# Altium schematic sheet USBINFRTransiever_IRTX.SchDoc
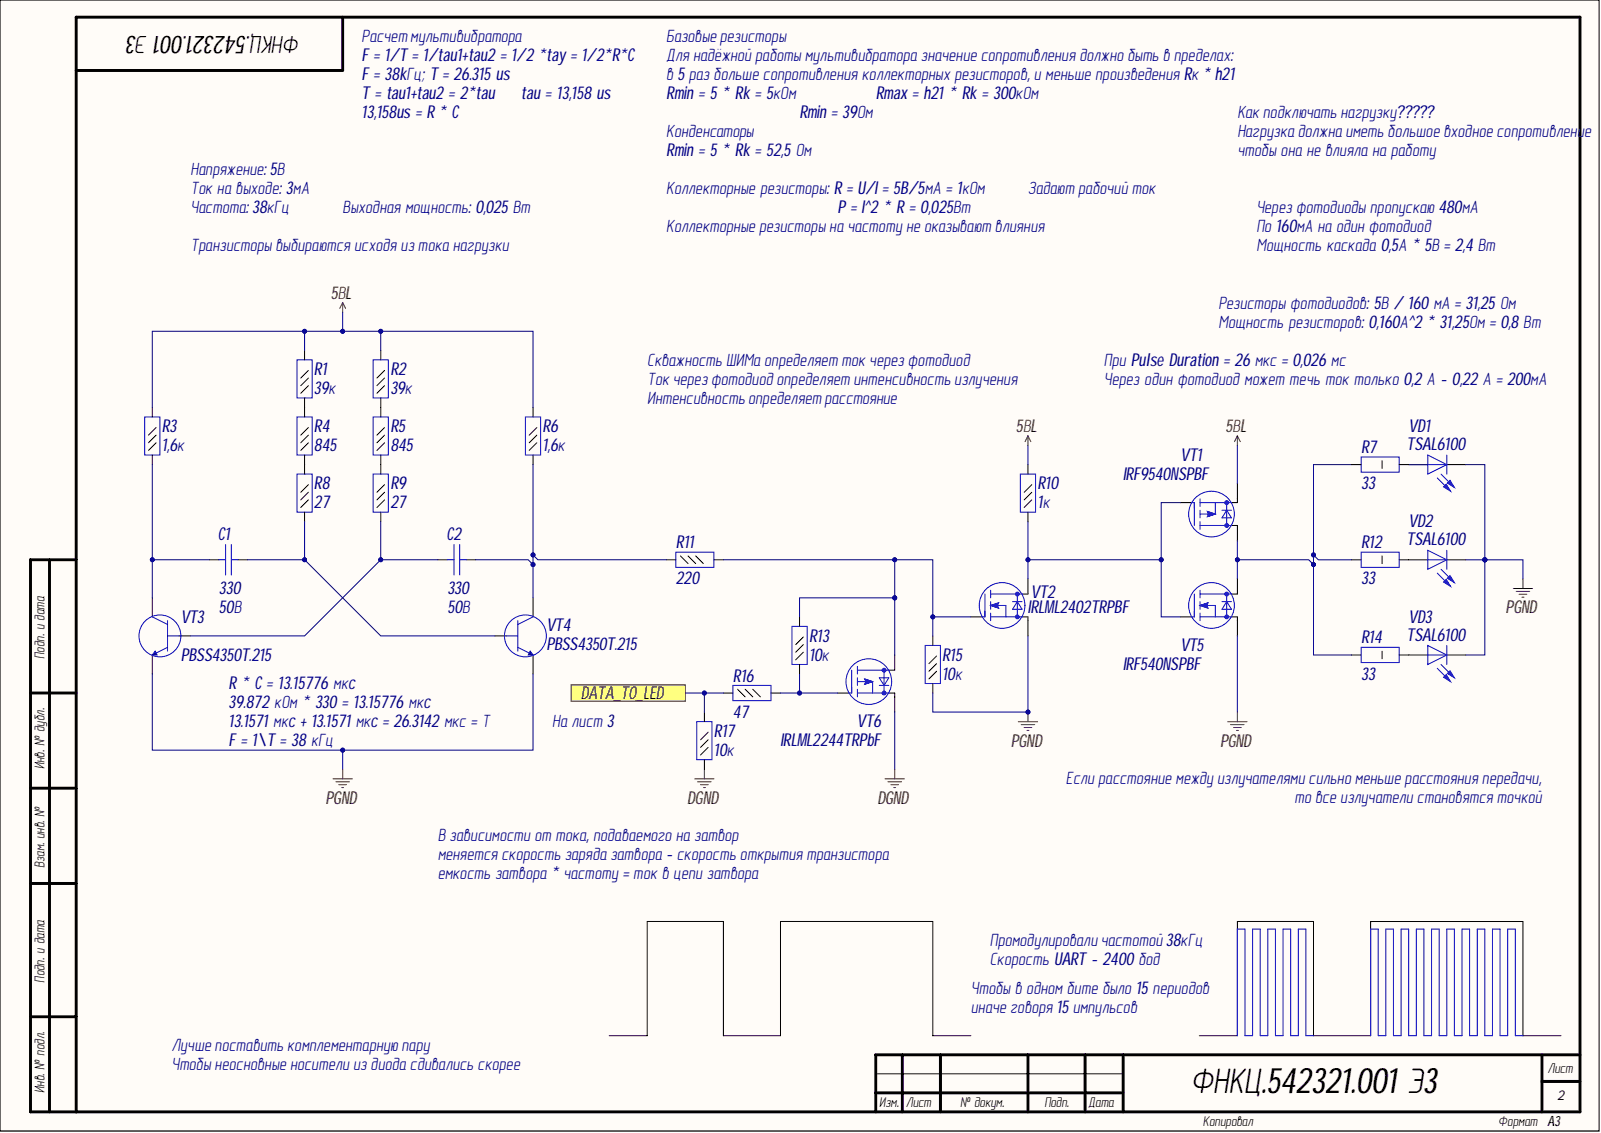
[diagram p0: USBINFRTransiever_IRTX.SchDoc]

## Parts
C1  value 330  [C0603]  (hidden comment: Capacitor)
C2  value 330  [C0603]  (hidden comment: Capacitor)
R1  value 39к  [R0603]  (hidden comment: Resistor_0,062Вт)
R2  value 39к  [R0603]  (hidden comment: Resistor_0,062Вт)
R3  value 1,6к  [R0603]  (hidden comment: Resistor_0,062Вт)
R4  value 845  [R0603]  (hidden comment: Resistor_0,062Вт)
R5  value 845  [R0603]  (hidden comment: Resistor_0,062Вт)
R6  value 1,6к  [R0603]  (hidden comment: Resistor_0,062Вт)
R7  value 33  [R2512]  (hidden comment: Resistor_1Вт)
R8  value 27  [R0603]  (hidden comment: Resistor_0,062Вт)
R9  value 27  [R0603]  (hidden comment: Resistor_0,062Вт)
R10  value 1к  [R0603]  (hidden comment: Resistor_0,062Вт)
R11  value 220  [R0603]  (hidden comment: Resistor_0,062Вт)
R12  value 33  [R2512]  (hidden comment: Resistor_1Вт)
R13  value 10к  [R0603]  (hidden comment: Resistor_0,062Вт)
R14  value 33  [R2512]  (hidden comment: Resistor_1Вт)
R15  value 10к  [R0603]  (hidden comment: Resistor_0,062Вт)
R16  value 47  [R0603]  (hidden comment: Resistor_0,062Вт)
R17  value 10к  [R0603]  (hidden comment: Resistor_0,062Вт)
VD1  Part Number: TSAL6100  [RADIAL_5MM]  (hidden comment: LED)
VD2  Part Number: TSAL6100  [RADIAL_5MM]  (hidden comment: LED)
VD3  Part Number: TSAL6100  [RADIAL_5MM]  (hidden comment: LED)
VT1  Part Number: IRF9540NSPBF  [D2PAK]  (hidden comment: P-MOSFET)
VT2  Part Number: IRLML2402TRPBF  [SOT23-3]  (hidden comment: N-MOSFET)
VT3  Part Number: PBSS4350T.215  [SOT23-3]  (hidden comment: BJT NPN)
VT4  Part Number: PBSS4350T.215  Vceo max: 50В  [SOT23-3]  (hidden comment: BJT NPN)
VT5  Part Number: IRF540NSPBF  [D2PAK]  (hidden comment: N-MOSFET)
VT6  Part Number: IRLML2244TRPbF  [SOT23-3]  (hidden comment: P-MOSFET)

## Ports
DATA_TO_LED

## Nets
5ВL: R1.2 R2.2 R3.2 R6.2 R10.2 VT1.2
DGND: R17.1 VT6.2
PGND: R15.1 VD1.1 VD2.1 VD3.1 VT2.2 VT3.2 VT4.2 VT5.2
DATA_TO_LED: R16.1 R17.2 ; port DATA_TO_LED
NetC1_1: C1.1 R3.1 VT3.3
NetC1_2: C1.2 R8.1 VT4.1
NetC2_1: C2.1 R9.1 VT3.1
NetC2_2: C2.2 R6.1 R11.1 VT4.3
NetR1_1: R1.1 R4.2
NetR2_1: R2.1 R5.2
NetR4_1: R4.1 R8.2
NetR5_1: R5.1 R9.2
NetR7_1: R7.1 R12.1 R14.1 VT1.3 VT5.3
NetR7_2: R7.2 VD1.2
NetR10_1: R10.1 VT1.1 VT2.3 VT5.1
NetR11_2: R11.2 R13.2 R15.2 VT2.1 VT6.3
NetR12_2: R12.2 VD2.2
NetR13_1: R13.1 R16.2 VT6.1
NetR14_2: R14.2 VD3.2

## Library data of the parts
C1 C2 = cap215: Конденсатор; Manufacture: Murata; Part Name: Ceramic; Part Number: GRM188R71H331KA01D; Database Table Name: Capacitors$; TKE: X7R; Voltage: 50В; Component Kind: Standard; id: cap215; Library Name: MOP44.DbLib
R1 R2 = res497: Резистор; Manufacture: Yageo; Part Number: RC0603FR-0739KL; Accuracy: 1%; Database Table Name: Resistor$; Component Kind: Standard; id: res497; Library Name: MOP44.DbLib; Part Name: Resistor; Power: 0,062Вт
R3 R6 = res464: Резистор; Manufacture: Yageo; Part Number: RC0603FR-071K6; Accuracy: 1%; Database Table Name: Resistor$; Component Kind: Standard; id: res464; Library Name: MOP44.DbLib; Part Name: Resistor; Power: 0,062Вт
R4 R5 = res971: Резистор; Manufacture: Yageo; Part Number: RC0603FR-07845RL; Database Table Name: Resistor$; Component Kind: Standard; id: res971; Library Name: MOP44.DbLib; Part Name: Resistor; Power: 0,062Вт
R7 R12 R14 = res809: Резистор; Manufacture: Yageo; Part Number: RC2512FR-0733RL; Accuracy: 1%; Database Table Name: Resistor$; Power: 1Вт; Component Kind: Standard; id: res809; Library Name: MOP44.DbLib; Part Name: Resistor
R8 R9 = res421: Резистор; Manufacture: Yageo; Part Number: RC0603FR-0727RL; Accuracy: 1%; Database Table Name: Resistor$; Component Kind: Standard; id: res421; Library Name: MOP44.DbLib; Part Name: Resistor; Power: 0,062Вт
R10 = res459: Резистор; Manufacture: Yageo; Part Number: RC0603FR-071KL; Accuracy: 1%; Database Table Name: Resistor$; Component Kind: Standard; id: res459; Library Name: MOP44.DbLib; Part Name: Resistor; Power: 0,062Вт
R11 = res443: Резистор; Manufacture: Yageo; Part Number: RC0603FR-07220RL; Accuracy: 1%; Database Table Name: Resistor$; Component Kind: Standard; id: res443; Library Name: MOP44.DbLib; Part Name: Resistor; Power: 0,062Вт
R13 R15 R17 = res483: Резистор; Manufacture: Yageo; Part Number: RC0603FR-0710KL; Accuracy: 1%; Database Table Name: Resistor$; Component Kind: Standard; id: res483; Library Name: MOP44.DbLib; Part Name: Resistor; Power: 0,062Вт
R16 = res427: Резистор; Manufacture: Yageo; Part Number: RC0603FR-0747RL; Accuracy: 1%; Database Table Name: Resistor$; Component Kind: Standard; id: res427; Library Name: MOP44.DbLib; Part Name: Resistor; Power: 0,062Вт
VD1-VD3 = phdiode1: Светодиод; Manufacture: Vishay; Part Name: High Power Infrared Emitting Diode; Angle of half intensity: (+-)10; Database Table Name: Photodiode$; Forward Current: 100mA; Forward Voltage: 1,35-2,6B; Max Reverse Voltage: 5B; Number of Channels: 1; Peak wavelength: 940nm; Radiant intensity: 80-400,1450mW/sr; Radiant power: 35mW; Surge Forward Current: 1,5A(impulse - 100us); Component Kind: Standard; id: phdiode1; Library Name: MOP44.DbLib
VT1 = trans6: N-MOSFET; Manufacturer: International Rectifier; Part Name: Transistor; Continuous drain current: (-23)A; Database Table Name: MOSFETTransistor$; Gate-to-source voltage: (+-)20B; Power dissipation: 140W; Pulsed drain current: (-92)A; Rds: 0,117Ohm; Structure: p-channel; V drain-source max: (-100B); Component Kind: Standard; id: trans6; Library Name: MOP44.DbLib
VT2 = trans2: Manufacturer: International Rectifier; Part Name: Transistor; Continuous drain current: 1,2A; Database Table Name: MOSFETTransistor$; Gate-to-source voltage: (+-)12B; Power dissipation: 0,54W; Pulsed drain current: 7,4A; Rds: 0,25Ohm; Structure: n-channel; V drain-source max: 20B; Component Kind: Standard; Description: N-MOSFET; id: trans2; Library Name: MOP44.DbLib
VT3 = bjt5: Manufacture: NXP Semiconductor; Part Name: Transistor; Database Table Name: BJTTransistor$; Frequency: 100МГц; hfe: 300; Ic max: 2А; Power: 0,48Вт; Vceo max: 50В; Component Kind: Standard; id: bjt5; Library Name: MOP44.DbLib; Structure: npn
VT4 = bjt5: Manufacture: NXP Semiconductor; Part Name: Transistor; Database Table Name: BJTTransistor$; Frequency: 100МГц; hfe: 300; Ic max: 2А; Power: 0,48Вт; Component Kind: Standard; id: bjt5; Library Name: MOP44.DbLib; Structure: npn
VT5 = trans7: Manufacturer: International Rectifier; Part Name: Transistor; Continuous drain current: 33A; Database Table Name: MOSFETTransistor$; Gate-to-source voltage: (+-)20B; Power dissipation: 130W; Pulsed drain current: 110A; Rds: 0,044Ohm; Structure: n-channel; V drain-source max: 100B; Component Kind: Standard; Description: N-MOSFET; id: trans7; Library Name: MOP44.DbLib
VT6 = trans5: N-MOSFET; Manufacturer: International Rectifier; Part Name: Transistor; Continuous drain current: (-4,3)A; Database Table Name: MOSFETTransistor$; Gate-to-source voltage: (+-)12B; Power dissipation: 1,3W; Pulsed drain current: (-18)A; Rds: 0,054Ohm; Structure: p-channel; V drain-source max: (-20B); Component Kind: Standard; id: trans5; Library Name: MOP44.DbLib
